# Altium schematic sheet MAIN.SchDoc
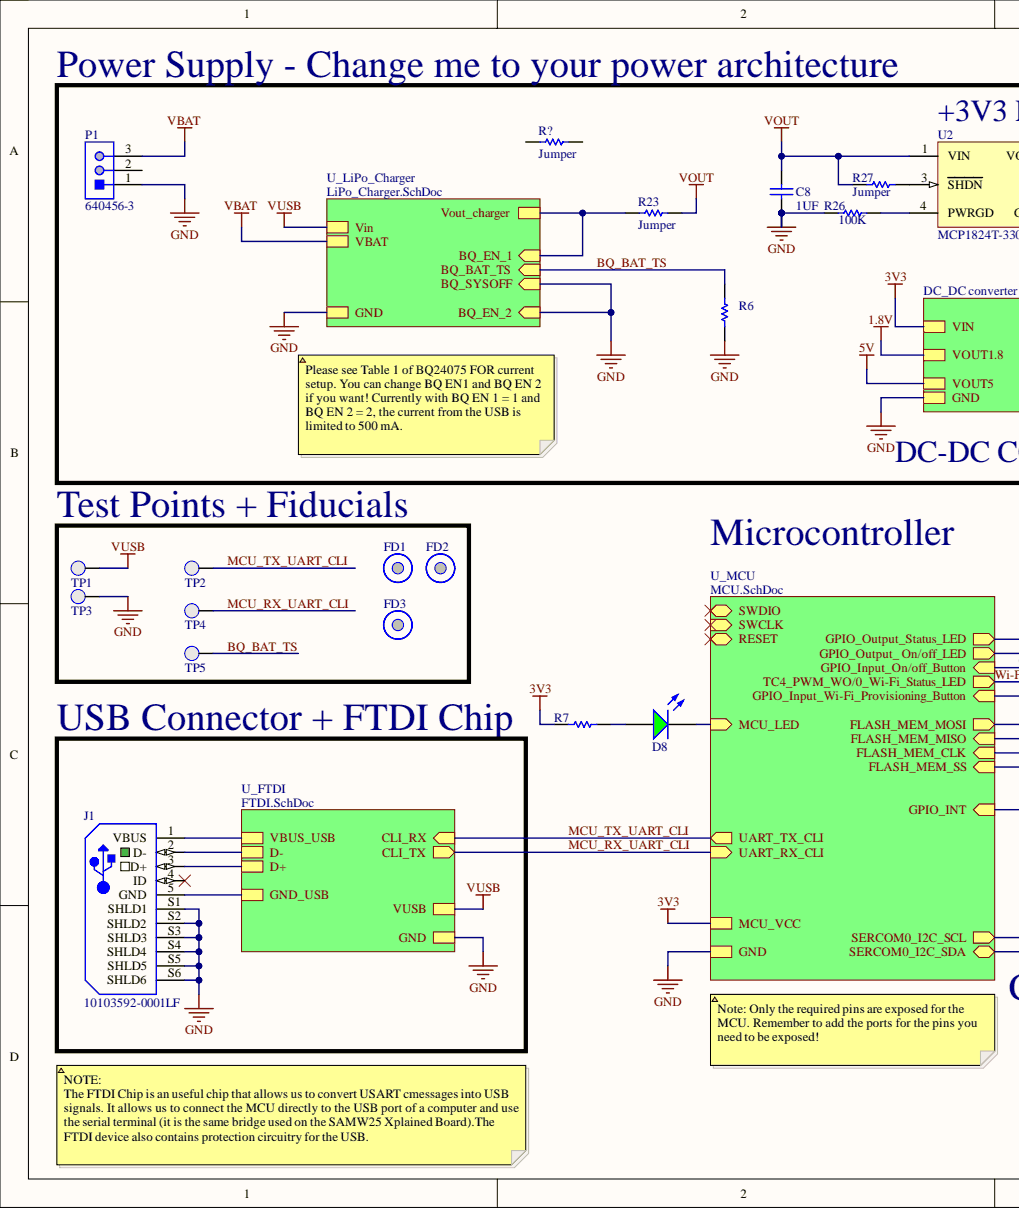
[diagram p0: MAIN.SchDoc, left]
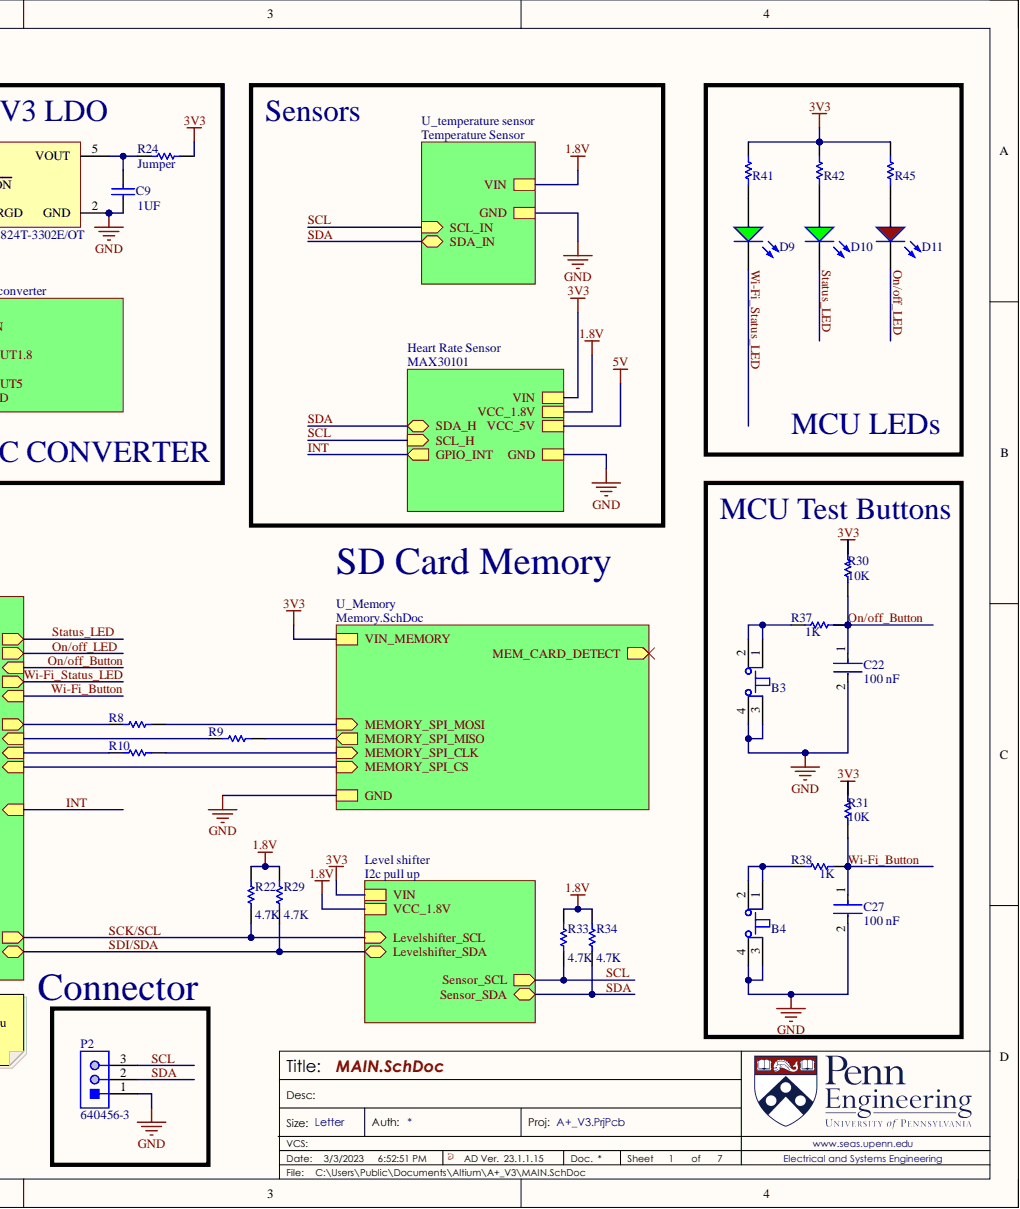
[diagram p1: MAIN.SchDoc, right]

## Parts
B3  PTS810SJK250SMTRLFS  [PTS810SJK250SMTRLFS-Footprint-1]  (value not drawn)
B4  PTS810SJK250SMTRLFS  [PTS810SJK250SMTRLFS-Footprint-1]  (value not drawn)
C8  1UF  [0603_CAP]
C9  1UF  [0603_CAP]
C22  100 nF  [FP-CC0805-0_85-MFG]
C27  100 nF  [FP-CC0805-0_85-MFG]
D8  LSM0805452V  [LED_0805]  (value not drawn)
D9  LSM0805452V  [LED_0805]  (value not drawn)
D10  LSM0805452V  [LED_0805]  (value not drawn)
D11  LSM0805412V  [LED_0805_RED]  (value not drawn)
FD1  Fiducial 40x100mm  [Fiducial 40x100mm-Footprint-1]  (value not drawn)
FD2  Fiducial 40x100mm  [Fiducial 40x100mm-Footprint-1]  (value not drawn)
FD3  Fiducial 40x100mm  [Fiducial 40x100mm-Footprint-1]  (value not drawn)
J1  10103592-0001LF  [10103592-0001LF-Footprint-1]
P1  640456-3  [TECO-640456-3]
P2  640456-3  [TECO-640456-3]
R6  RES 0603 1K 1%  [0603_RES]  (value not drawn)
R7  RES 0603 1K 1%  [0603_RES]  (value not drawn)
R8  RES 0603 27 1%  [0603_RES]  (value not drawn)
R9  RES 0603 27 1%  [0603_RES]  (value not drawn)
R10  RES 0603 27 1%  [0603_RES]  (value not drawn)
R22  value 4.7K  [0402_RES]  (hidden comment: RES 0402 4.7K 1%)
R23  Jumper  [0805_RES]
R24  Jumper  [0805_RES]
R26  100K  [0603_RES]
R27  Jumper  [0805_RES]
R29  value 4.7K  [0402_RES]  (hidden comment: RES 0402 4.7K 1%)
R30  10K  [0603_RES]
R31  10K  [0603_RES]
R33  value 4.7K  [0402_RES]  (hidden comment: RES 0402 4.7K 1%)
R34  value 4.7K  [0402_RES]  (hidden comment: RES 0402 4.7K 1%)
R37  1K  [0603_RES]
R38  1K  [0603_RES]
R41  Jumper  [0805_RES]  (value not drawn)
R42  Jumper  [0805_RES]  (value not drawn)
R45  Jumper  [0805_RES]  (value not drawn)
R?  Jumper  [0805_RES]
TP1  Test Point 0.1"  [Test Point 0.1"-Footprint-1]  (value not drawn)
TP2  Test Point 0.1"  [Test Point 0.1"-Footprint-1]  (value not drawn)
TP3  Test Point 0.1"  [Test Point 0.1"-Footprint-1]  (value not drawn)
TP4  Test Point 0.1"  [Test Point 0.1"-Footprint-1]  (value not drawn)
TP5  Test Point 0.1"  [Test Point 0.1"-Footprint-1]  (value not drawn)
U2  MCP1824T-3302E/OT  [SOT-23-OT5_L]

## Nets
1.8V: R22.2 R29.2 R33.2 R34.2
3V3: R7.2 R24.2 R30.2 R41.1 R42.1 R45.1
GND: B3.3 B3.4 B4.3 B4.4 C8.1 C9.1 C22.2 C27.2 J1.S1(SHLD1) J1.S2(SHLD2) J1.S3(SHLD3) J1.S4(SHLD4) J1.S5(SHLD5) J1.S6(SHLD6) P1.1 P2.1 R6.1 R26.1 TP3.1 U2.2(GND)
VBAT: P1.3
VOUT: C8.2 R23.2 R27.1 U2.1(VIN)
VUSB: TP1.1
BQ_BAT_TS_1: R6.2 TP5.1  [also labelled BQ_BAT_TS]
MCU_RX_UART_CLI_1: TP4.1  [also labelled MCU_RX_UART_CLI]
MCU_TX_UART_CLI_1: TP2.1  [also labelled MCU_TX_UART_CLI]
On/off_Button_1: C22.1 R30.1 R37.1  [also labelled On/off_Button]
On/off_LED_1: D11.K  [also labelled On/off_LED]
SCK/SCL_1: R22.1  [also labelled SCK/SCL]
SCL_1: P2.3 R33.1  [also labelled SCL]
SDA_1: P2.2 R34.1  [also labelled SDA]
SDI/SDA_1: R29.1  [also labelled SDI/SDA]
Status_LED_1: D10.K  [also labelled Status_LED]
Wi-Fi_Button_1: C27.1 R31.1 R38.1  [also labelled Wi-Fi_Button]
Wi-Fi_Status_LED_1: D9.K  [also labelled Wi-Fi_Status_LED]
NetB3_1: B3.1 B3.2 R37.2
NetB4_1: B4.1 B4.2 R38.2
NetC9_2: C9.2 R24.1 U2.5(VOUT)
NetD8_A: D8.A R7.1
NetD8_K: D8.K
NetD9_A: D9.A R41.2
NetD10_A: D10.A R42.2
NetD11_A: D11.A R45.2
NetJ1_1: J1.1(VBUS)
NetJ1_2: J1.2(D-)
NetJ1_3: J1.3(D+)
NetJ1_4: J1.4(ID)
NetJ1_5: J1.5(GND)
NetP1_2: P1.2
NetR8_1: R8.1
NetR8_2: R8.2
NetR9_1: R9.1
NetR9_2: R9.2
NetR10_1: R10.1
NetR10_2: R10.2
NetR23_1: R23.1
NetR26_2: R26.2 U2.4(PWRGD)
NetR27_2: R27.2 U2.3(SHDN)
NetR31_2: R31.2
NetR?_1: R?.1
NetR?_2: R?.2
5V:
FLASH_MEM_SS_1:
INT_1:  [also labelled INT]
MEM_CARD_DETECT_1:
RESET_1:
SWCLK_1:
SWDIO_1:

## Library data of the parts
B3 B4 = CMP-00084-2: Keypad Switch, 1 Switches, SPST, Momentary-tactile, 0.05A, 16VDC, 3.23N, Solder Terminal, Surface Mount-straight; Manufacturer Series: PTS810; Actuator Color: White; Actuator Length: 0.028inch; Actuator Travel: 0.1524mm; Actuator Type: Pushbutton; Contact Resistance: 0.5mR; Dielectric Withstand Volts: 250VACV; Electrical Life: 50000Cycles; Insulation Resistance: 100MR; Solderability: REFLOW; Switch Function: OFF-MOM; Circuit: SPST; Component Kind: Standard; JESD-609 Code: e4; Library Name: University of Pennsylvania (ESE Labs); Max Operating Temperature: 85°C; Min Operating Temperature: -40°C; Mounting Technology: Surface Mount; Reach SVHC Compliant: Yes; RoHS Compliant: Yes; Switch Action: MOMENTARY; Switch Type: Keypad; Terminal Finish: Silver; Termination: Solder; Type: Tactile
C8 C9 = CMP-011-000286-1: Capacitor Surface Mount 0603 Footprint 1UF 16V 10% Tolerance Ceramic X7R; Manufacturer: Various; Manufacturer P/N: CAP 0603 1UF 16V 10% X7R; Description in Visual: Cap SMT 0603 1UF 16V 10% Ceramic X7R; PCB Package: 0603; Component Kind: Standard; Library Name: University of Pennsylvania (ESE Labs); Mount: SMT; P/N: CAP 0603 1UF 16V 10% X7R; Tolerance: 10%; Type: Ceramic X7R; Value: 1UF; Voltage: 16V
C22 C27 = CMP-2007-01272-2: CC0805 100 nF X7R 10.00% 50 V; Manufacturer: Yageo; Manufacturer Part Number: CC0805KPX7R9BB104; Material: Ceramic; Tolerance: 0.1; Voltage Rating: 50 V; Automotive: No; Capacitance: 100 nF; Category: Cap; Component Kind: Standard; ComponentLink2Description: Datasheet; ComponentLink2URL: http://www.yageo.com/documents/recent/UPY-GPHC_Y5V_6.3V-to-50V_8.pdf; Device Class L1: Passive Components; Device Class L2: Capacitors; Device Class L3: Ceramic Capacitors; Footprint Url: http://www.yageo.com/exep/pages/download/literatures/UPY-C_GEN_24.pdf; Height: 0.95mm; Ipc Land Pattern Name: CAPC200125X85; Lead Free: Yes; Library Name: Altium Content Vault; Mouser Description: 0805 0.1 uF 50 V ±10% Tolerance X7R SMT Multilayer Ceramic Capacitor; Octopart: https://octopart.com/cc0805kpx7r9bb104-yageo-12756114; Package: CC0805-0.85; RoHS: TRUE; Temperature: -30°C to +85°C; Temperature Characteristic: X7R; Temperature Range High: +85°C; Temperature Range Low: -30°C; Value: 100 nF
D8-D10 = CMP-00038-3: Single Color LED, Green, 0805; Forward Voltage: 2V; Test Current: 20mA; Component Kind: Standard; Library Name: University of Pennsylvania (ESE Labs); RoHS Compliant: Yes
D11 = CMP-00026-00001-1: Single Color LED, RED, 0805; Forward Voltage: 2V; Test Current: 20mA; Component Kind: Standard; Library Name: University of Pennsylvania (ESE Labs); RoHS Compliant: Yes
FD1-FD3 = CMP-00085-1: Component Kind: Standard; Description: Fiducial 40x100mm; Library Name: University of Pennsylvania (ESE Labs)
J1 = CMP-00092-5: Conn Micro USB 2.0 Type B RCP 5 POS 0.65mm Solder RA SMD 5 Terminal 1 Port T/R; Component Kind: Standard; Library Name: University of Pennsylvania (ESE Labs)
P1 P2 = CMP-2000-05418-1: Male Header, Pitch 2.54 mm, 1 x 3 Position, Height 10.03 mm, Tail L ength 3.56 mm, -55 to 105 degC; Manufacturer: TE Connectivity; Agency Approvals: CSA,UL; Angle: Straight; Brand/Series: MTA-100Series; Color, Housing: White; Connector Type: MTA-100; Contact Centerline Spacing: 0.100    in.; Contact Termination Area Plating: Tin; Current, Rating: 5    A; Material, Contact: CopperAlloy; Material, Housing: Polyester; Mount Angle: Vertical; Mounting Hole Size: 0.04    "; Number of Contacts: 3; Pin Spacing: 0.1    "; Primary Type: InterconnectSystem; Special Features: Pinstrip; Standards: RoHSCompliant,ELVCompliant,UL94V-0; Temperature, Operating: -55to+105    degC; Voltage, Rating: 250    V; Color: White; Component Kind: Standard; Contact Mating Area Plating: Tin; Contact Plating: Tin; Contact Shape: Square; Datasheet URL: https://datasheet.ciiva.com/16456/eng-ds-82056-mta-0608-16456886.pdf; Datasheet Version: Jun-08; Flammability Rating: UL94V-0; Length, Overall: 0.3    "; Length, Pin: 0.14    "; Length, Terminal Post: 0.14    in.; Library Name: Altium Content Vault; Manufacturer URL: http://www.te.com/; Mounting Type: PCMount; Number of Positions: 3; Number of Rows: 1; Size, Post: 0.025    in.; Termination: Solder; Type: PCBPlug
R6 R7 = CMP-005-002503-2: Resistor Surface Mount, 1K Ohms, 0603 Footprint, 1% Tolerance 0.1W; Manufacturer: Various; Description in Visual: Res SMT 1K 0603  1%  0.1W; PCB Package: 0603; Component Kind: Standard; JLCPCB Part #: C21190; Library Name: University of Pennsylvania (ESE Labs); Manufacturer P/N: RES 0603 1K 1%; Mount: SMT; Power Rating: 0.1W; Tolerance: 1%; Value: 1k
R8-R10 = CMP-005-002764-1: Resistor Surface Mount, 27 Ohms, 0603 Footprint, 1% Tolerance 0.1W; Manufacturer: Various; Manufacturer P/N: RES 0603 27R 1%; Description in Visual: Res SMT 27 0603  1%  0.1W; PCB Package: 0603; Value: 27; Component Kind: Standard; Library Name: University of Pennsylvania (ESE Labs); Mount: SMT; Power Rating: 0.1W; Tolerance: 1%
R22 R29 R33 R34 = CMP-005-001239-3: Resistor Surface Mount, 4.7K Ohms, 0402 Footprint, 1% Tolerance 0.063W; Manufacturer: Various; Description in Visual: Res SMT 4.7K 0402  1%  0.063W; PCB Package: 0402; Component Kind: Standard; Library Name: University of Pennsylvania (ESE Labs); Manufacturer P/N: RES 0402 4.7K 1%; Mount: SMT; Power Rating: 0.063W; Tolerance: 1%
R23 R24 R27 R41 R42 R45 R? = CMP-005-003861-1: Resistor Surface Mount, 0 Ohms, 0805 Footprint, 5% Tolerance 0.125W; Manufacturer: Various; Manufacturer P/N: RES 0805 0R 5%; Description in Visual: Res SMT 0 0805  5%  0.125W; PCB Package: 0805; Value: 0; Component Kind: Standard; Library Name: University of Pennsylvania (ESE Labs); Mount: SMT; Power Rating: 0.125W; Tolerance: 5%
R26 = CMP-005-002134-1: Resistor Surface Mount, 100K Ohms, 0603 Footprint, 5% Tolerance 0.1W; Manufacturer: Various; Manufacturer P/N: RES 0603 100K 5%; Description in Visual: Res SMT 100K 0603  5%  0.1W; PCB Package: 0603; Component Kind: Standard; Library Name: University of Pennsylvania (ESE Labs); Mount: SMT; Power Rating: 0.1W; Tolerance: 5%; Value: 100K
R30 R31 = CMP-005-002156-2: Resistor Surface Mount, 10K Ohms, 0603 Footprint, 1% Tolerance 0.1W; Manufacturer: Various; Manufacturer P/N: RES 0603 10K 1%; Description in Visual: Res SMT 10K 0603  1%  0.1W; PCB Package: 0603; Component Kind: Standard; JLCPCB Part #: C25804; Library Name: University of Pennsylvania (ESE Labs); Mount: SMT; Power Rating: 0.1W; Tolerance: 1%; Value: 10k
R37 R38 = CMP-005-002503-2: Resistor Surface Mount, 1K Ohms, 0603 Footprint, 1% Tolerance 0.1W; Manufacturer: Various; Manufacturer P/N: RES 0603 1K 1%; Description in Visual: Res SMT 1K 0603  1%  0.1W; PCB Package: 0603; Component Kind: Standard; JLCPCB Part #: C21190; Library Name: University of Pennsylvania (ESE Labs); Mount: SMT; Power Rating: 0.1W; Tolerance: 1%; Value: 1k
TP1-TP5 = CMP-00086-1: Component Kind: Standard; Description: Test Point 0.1"; Library Name: University of Pennsylvania (ESE Labs)
U2 = CMP-0136-00741-1: 300 mA, Low Voltage, Low Quiescent Current LDO Regulator, 5-Pin SOT-23, Extended Temperature, Tape and Reel; Code_IPC: SOT23-5; Component Kind: Standard; DatasheetVersion: revA, Aug-2007; Library Name: Altium Content Vault; PackageDescription: 5-Lead Plastic Small Outline Transistor (OT) [SOT-23]; PackageReference: SOT-23-OT5; PackageVersion: revBB, Aug-2009
